# Altium schematic sheet Raijin_Motors.SchDoc
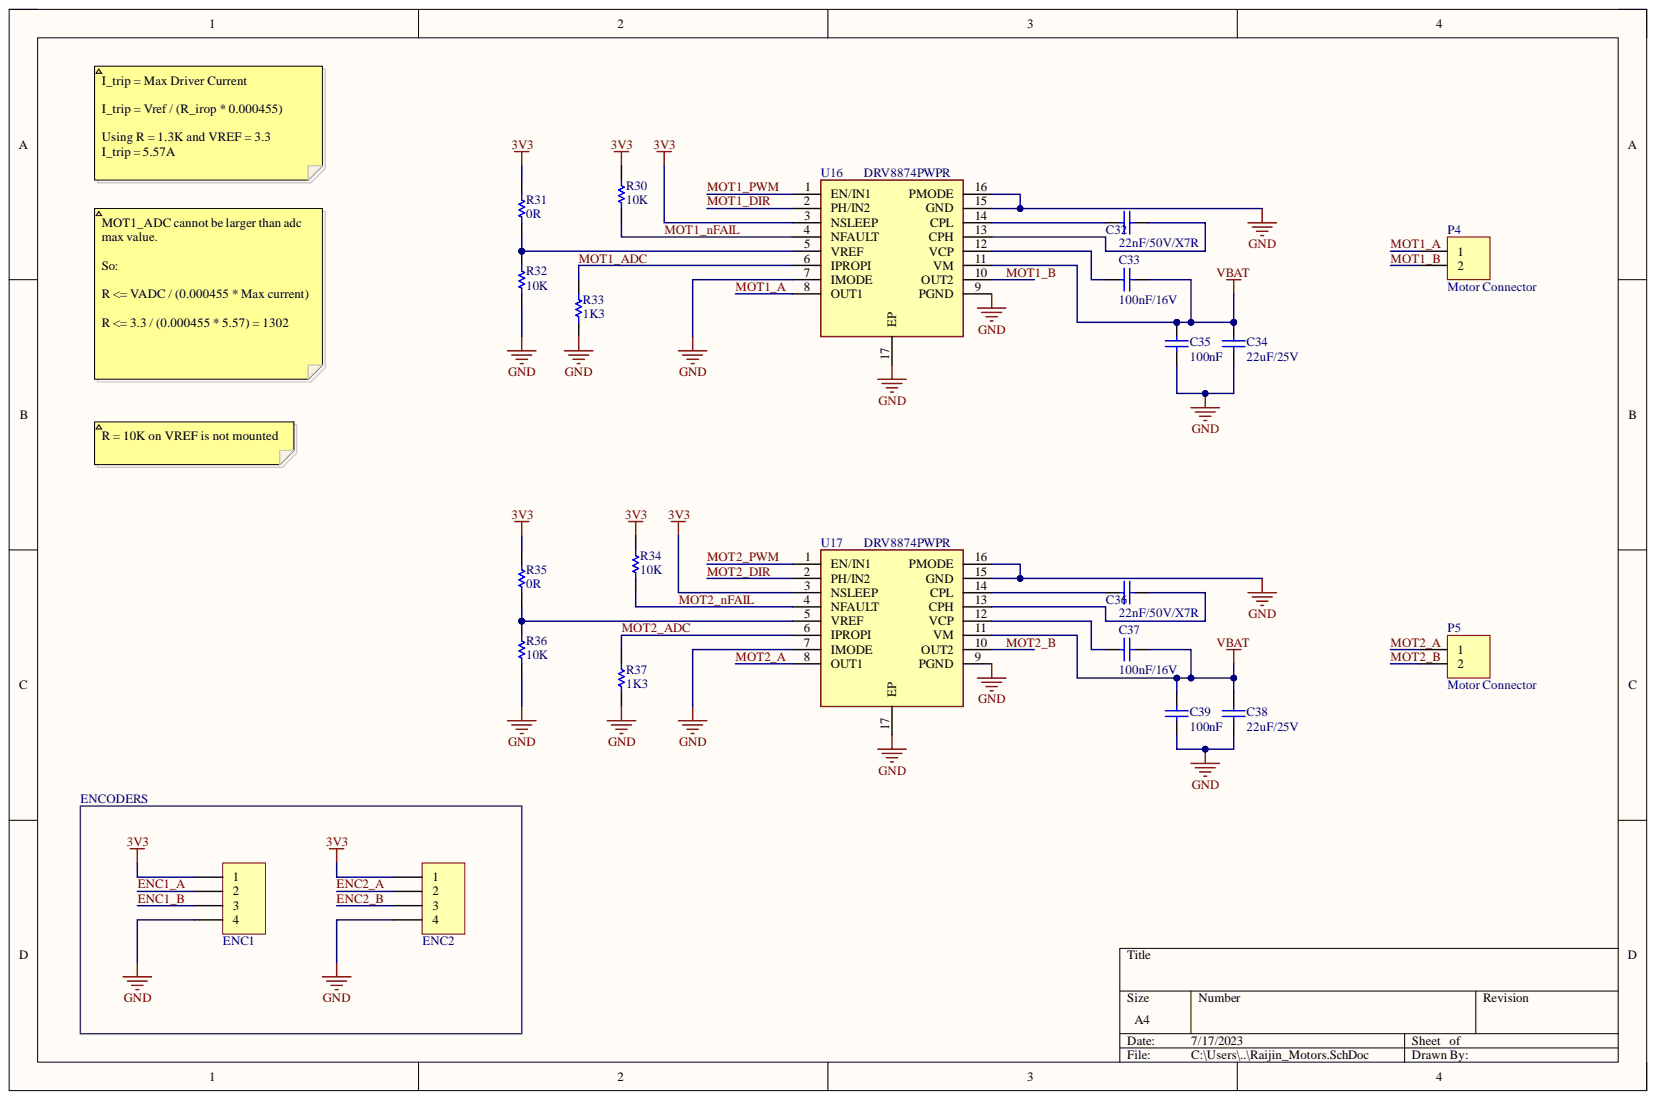
[diagram p0: Raijin_Motors.SchDoc]

## Parts
C32  22nF/50V/X7R  [Capacitor-0603]
C33  100nF/16V  [Capacitor-0603]
C34  22uF/25V  [CAPACITOR-0805]
C35  100nF  [Capacitor-0603]
C36  22nF/50V/X7R  [Capacitor-0603]
C37  100nF/16V  [Capacitor-0603]
C38  22uF/25V  [CAPACITOR-0805]
C39  100nF  [Capacitor-0603]
ENC1  Header 1x4  [HDR1X4]  (value not drawn)
ENC2  Header 1x4  [HDR1X4]  (value not drawn)
P4  Motor Connector  [MOTOR_CONNECTOR]
P5  Motor Connector  [MOTOR_CONNECTOR]
R30  10K  [RESISTOR 0603]
R31  0R  [RESISTOR 0603]
R32  10K  [RESISTOR 0603]
R33  1K3  [RESISTOR 0603]
R34  10K  [RESISTOR 0603]
R35  0R  [RESISTOR 0603]
R36  10K  [RESISTOR 0603]
R37  1K3  [RESISTOR 0603]
U16  DRV8874PWPR  [SOP65P640X120-17N]
U17  DRV8874PWPR  [SOP65P640X120-17N]

## Nets
3V3: ENC1.1 ENC2.1 R30.2 R31.2 R34.2 R35.2 U16.3(NSLEEP) U17.3(NSLEEP)
GND: C34.1 C35.1 C38.1 C39.1 ENC1.4 ENC2.4 R32.1 R33.1 R36.1 R37.1 U16.7(IMODE) U16.9(PGND) U16.15(GND) U16.16(PMODE) U16.17(EP) U17.7(IMODE) U17.9(PGND) U17.15(GND) U17.16(PMODE) U17.17(EP)
VBAT: C33.1 C34.2 C35.2 C37.1 C38.2 C39.2 U16.11(VM) U17.11(VM)
ENC1_A: ENC1.2
ENC1_B: ENC1.3
ENC2_A: ENC2.2
ENC2_B: ENC2.3
MOT1_A: P4.1 U16.8(OUT1)
MOT1_ADC: R33.2 U16.6(IPROPI)
MOT1_B: P4.2 U16.10(OUT2)
MOT1_DIR: U16.2(PH/IN2)
MOT1_nFAIL: R30.1 U16.4(NFAULT)
MOT1_PWM: U16.1(EN/IN1)
MOT2_A: P5.1 U17.8(OUT1)
MOT2_ADC: R37.2 U17.6(IPROPI)
MOT2_B: P5.2 U17.10(OUT2)
MOT2_DIR: U17.2(PH/IN2)
MOT2_nFAIL: R34.1 U17.4(NFAULT)
MOT2_PWM: U17.1(EN/IN1)
NetC32_1: C32.1 U16.14(CPL)
NetC32_2: C32.2 U16.13(CPH)
NetC33_2: C33.2 U16.12(VCP)
NetC36_1: C36.1 U17.14(CPL)
NetC36_2: C36.2 U17.13(CPH)
NetC37_2: C37.2 U17.12(VCP)
NetR31_1: R31.1 R32.2 U16.5(VREF)
NetR35_1: R35.1 R36.2 U17.5(VREF)

## Library data of the parts
C32 C36 = CAP 22nF: Capacitor Cer 22nF 50V; Manufacturer Part Number: IMP14925; Capacitance Tolerance: +80%, -20%; Capacitor Terminals: Radial Leaded; Dielectric Characteristic: Y5; Product Range: MCFY Series; SVHC: No SVHC (15-Jun-2015); Voltage Rating: 50; Capacitance Tolerance ±: +80%, -20%; Component Kind: Standard; ComponentLink1Description: http://loja.multcomercial.com.br/capacitor-ceramico-disco-100kpf-50v-104-100k-100nf-0-1uf-cod-1351.html; ComponentLink1URL: http://loja.multcomercial.com.br/capacitor-ceramico-disco-100kpf-50v-104-100k-100nf-0-1uf-cod-1351.html; Lead Spacing: 5; Manufacturer: -; Operating Temperature Max: 85; Operating Temperature Min: -25; Operating Temperature Range: -25°C to +85°C<!--FANDB--><div class="features-and-benefits-container"><div class="features-and-benefits-description"><span class="features-benefits-value">The MCFY series from Multicomp are radial leaded ceramic disc capacitors. The capacitance values ranges from 6800pF to 0.22?F.</span></div><div class="features-and-benefits-list"><ul class="features-and-benefits-list-list"><li class="features-and-benefits-list-item">Large capacitance in small size</li><li class="features-and-benefits-list-item">Rated voltage of 50V</li><li class="features-and-benefits-list-item">80%/-20% tolerance</li><li class="features-and-benefits-list-item">Operating temperature range -25°C to +85; Packaging: Each; Pricing 1: 1=0.10 (BRL); RoHS: YES; Stock 1: 115809; Supplier 1: Mult Comercial; Supplier Part Number 1: Capacitor Cerâmico Disco 100Kpf/50V (104/100K/100nF/0.1uF) cód. 1351; Library Name: RaijinLib.SchLib
C33 C37 = CAP 100NF 16V: Capacitor Cer 100nF 16VX7R; Manufacturer Part Number: IMP14925; Capacitance: 0.1uF; Capacitance Tolerance: +80%, -20%; Capacitor Terminals: Radial Leaded; Dielectric Characteristic: Y5; Product Range: MCFY Series; SVHC: No SVHC (15-Jun-2015); Voltage Rating: 50; Capacitance Tolerance ±: +80%, -20%; Component Kind: Standard; ComponentLink1Description: http://loja.multcomercial.com.br/capacitor-ceramico-disco-100kpf-50v-104-100k-100nf-0-1uf-cod-1351.html; ComponentLink1URL: http://loja.multcomercial.com.br/capacitor-ceramico-disco-100kpf-50v-104-100k-100nf-0-1uf-cod-1351.html; Lead Spacing: 5; Manufacturer: -; Operating Temperature Max: 85; Operating Temperature Min: -25; Operating Temperature Range: -25°C to +85°C<!--FANDB--><div class="features-and-benefits-container"><div class="features-and-benefits-description"><span class="features-benefits-value">The MCFY series from Multicomp are radial leaded ceramic disc capacitors. The capacitance values ranges from 6800pF to 0.22?F.</span></div><div class="features-and-benefits-list"><ul class="features-and-benefits-list-list"><li class="features-and-benefits-list-item">Large capacitance in small size</li><li class="features-and-benefits-list-item">Rated voltage of 50V</li><li class="features-and-benefits-list-item">80%/-20% tolerance</li><li class="features-and-benefits-list-item">Operating temperature range -25°C to +85; Packaging: Each; Pricing 1: 1=0.10 (BRL); RoHS: YES; Stock 1: 115809; Supplier 1: Mult Comercial; Supplier Part Number 1: Capacitor Cerâmico Disco 100Kpf/50V (104/100K/100nF/0.1uF) cód. 1351; Library Name: RaijinLib.SchLib
C34 C38 = CAP CER 22UF 25V X5R 0805: Manufacturer: AVX Corporation; Manufacturer Part Number: 0805YD106KAT2A; Capacitance: 10µF; Tolerance: ±10%; Voltage - Rated: 16V; Applications: General Purpose; Category: Capacitors; Component Kind: Standard; ComponentLink1Description: http://datasheets.avx.com/cx5r.pdf; ComponentLink1URL: https://www.lcsc.com/product-detail/Multilayer-Ceramic-Capacitors-MLCC-SMD-SMT_Murata-Electronics-GRM21BR61E226ME44L_C86816.html; Description: CAP CER 22UF 25V X5R 0805; Features: -; Height - Seated (Max): -; Lead Spacing: -; Lead Style: -; Mounting Type: Surface Mount, MLCC; Operating Temperature: -55°C ~ 85°C; Package / Case: 0805 (2012 Metric); Packaging: Cut Tape (CT); Part Status: Active; Pricing 1: 1=0.18, 10=0.124, 25=0.0908, 50=0.0742, 100=0.0627, 250=0.05116, 500=0.0429, 1000=0.03795 (USD); Ratings: -; RoHS: RoHS Compliant; Size / Dimension: 0.079" L x 0.049" W (2.01mm x 1.25mm); Stock 1: 198871; Supplier 1: Digi-Key; Supplier Part Number 1: 478-5165-1-ND; Temperature Coefficient: X5R; Thickness (Max): 0.055" (1.40mm); Library Name: RaijinLib.SchLib
C35 C39 = CAP 100NF 50V: Capacitor Cer 100nF 50V; Manufacturer Part Number: IMP14925; Capacitance: 0.1uF; Capacitance Tolerance: +80%, -20%; Capacitor Terminals: Radial Leaded; Dielectric Characteristic: Y5; Product Range: MCFY Series; SVHC: No SVHC (15-Jun-2015); Voltage Rating: 50; Capacitance Tolerance ±: +80%, -20%; Component Kind: Standard; ComponentLink1Description: http://loja.multcomercial.com.br/capacitor-ceramico-disco-100kpf-50v-104-100k-100nf-0-1uf-cod-1351.html; ComponentLink1URL: http://loja.multcomercial.com.br/capacitor-ceramico-disco-100kpf-50v-104-100k-100nf-0-1uf-cod-1351.html; Lead Spacing: 5; Manufacturer: -; Operating Temperature Max: 85; Operating Temperature Min: -25; Operating Temperature Range: -25°C to +85°C<!--FANDB--><div class="features-and-benefits-container"><div class="features-and-benefits-description"><span class="features-benefits-value">The MCFY series from Multicomp are radial leaded ceramic disc capacitors. The capacitance values ranges from 6800pF to 0.22?F.</span></div><div class="features-and-benefits-list"><ul class="features-and-benefits-list-list"><li class="features-and-benefits-list-item">Large capacitance in small size</li><li class="features-and-benefits-list-item">Rated voltage of 50V</li><li class="features-and-benefits-list-item">80%/-20% tolerance</li><li class="features-and-benefits-list-item">Operating temperature range -25°C to +85; Packaging: Each; Pricing 1: 1=0.10 (BRL); RoHS: YES; Stock 1: 115809; Supplier 1: Mult Comercial; Supplier Part Number 1: Capacitor Cerâmico Disco 100Kpf/50V (104/100K/100nF/0.1uF) cód. 1351; Library Name: RaijinLib.SchLib
ENC1 ENC2 = Header 1x4: Headers & Wire Housings 40Pin 2mm Spacing Single Row Hdrs, 5pc; Manufacturer Part Number: IMP10327; Brand: Cromax; Mounting Angle: Vertical; Number of Positions: 40 Position; Accessory Type: -; Category: Headers & Wire Housings; Component Kind: Standard; ComponentLink1Description: http://loja.multcomercial.com.br/barra-de-pinos-simples-1x40-macho-bmo40-1e.html; ComponentLink1URL: http://loja.multcomercial.com.br/barra-de-pinos-simples-1x40-macho-bmo40-1e.html; Contact Gender: Pin (Male); Contact Plating: Gold; Contact Type: -; Manufacturer: Cromax; Minimum Order Quantity: 1; Mounting Style: Straight Pin; Number of Rows: 1 Row; Pitch: 2 mm; Pricing 1: 1=1.00, 5=0.20 (BRL); Product: Headers; Product Category: Accessories; Reeling: False; RoHS: RoHS Compliant; RoHS - Mouser: Y; Row Spacing: -; Stock 1: 14; Supplier 1: Mult Comercial; Supplier Part Number 1: Barra de Pinos Simples 1x40 Macho BMO40-1E; Termination Style: Through Hole; Type: Breakaway; Library Name: RaijinLib.SchLib
R30 R32 R34 R36 = RES 10K 5%: Manufacturer: PANASONIC ELECTRONIC COMPONENTS; Manufacturer Part Number: ERJ-3GEYJ103V; Power (Watts): 0.1W, 1/10W; Temperature Coefficient: ±200ppm/°C; Tolerance: ±5%; Category: Resistors; Component Kind: Standard; ComponentLink1Description: http://www.farnell.com/datasheets/1678796.pdf; ComponentLink1URL: http://www.farnell.com/datasheets/1678796.pdf; ComponentLink2Description: http://industrial.panasonic.com/www-cgi/jvcr13pz.cgi?E+PZ+3+AOA0001+ERJ3GEYJ103V+7+WW; ComponentLink2URL: http://industrial.panasonic.com/www-cgi/jvcr13pz.cgi?E+PZ+3+AOA0001+ERJ3GEYJ103V+7+WW; Composition: Thick Film; Features: Automotive AEC-Q200; Height: 0.022" (0.55mm); Number of Terminations: 2; Operating Temperature: -55°C ~ 155°C; Package / Case: 0603 (1608 Metric); Packaging: Tape & Reel (TR); Resistance (Ohms): 10k; RoHS: YES; Size / Dimension: 0.063" L x 0.031" W (1.60mm x 0.80mm); Supplier Device Package: 0603; Library Name: RaijinLib.SchLib
R31 R35 = RES 0R: Manufacturer: Ty-Ohm; Manufacturer Part Number: CR25; Power (Watts): 0.25W, 1/4W; Temperature Coefficient: 0/ -500ppm/°C; Tolerance: ±5%; Category: Resistors; Component Kind: Standard; ComponentLink1Description: http://loja.multcomercial.com.br/resistor-de-1-4-de-watt-cr25-5-de-tolerancia.html; ComponentLink1URL: http://loja.multcomercial.com.br/resistor-de-1-4-de-watt-cr25-5-de-tolerancia.html; Composition: Carbon Film; Features: Flame Retardant Coating, Safety; Height: -; Number of Terminations: 2; Operating Temperature: -55°C ~ 155°C; Package / Case: Axial; Packaging: Cut Tape (CT); Pricing 1: 1=0.20 (BRL); Resistance (Ohms): ?; RoHS: RoHS Compliant; Size / Dimension: 0.091" Dia x 0.256" L (2.30mm x 6.50mm); Stock 1: 617543; Supplier 1: Mult Comercial; Supplier Device Package: Axial; Supplier Part Number 1: Resistor de Filme de Carbono 1/4 de Watt CR25 5% de tolerância - 0.22R Ω à 10M Ω; Library Name: RaijinLib.SchLib
R33 R37 = RES 1K3 1%: Resistor 1K3 1% tolerance; Manufacturer: Ty-Ohm; Manufacturer Part Number: CR25; Power (Watts): 0.25W, 1/4W; Temperature Coefficient: 0/ -500ppm/°C; Tolerance: ±5%; Category: Resistors; Component Kind: Standard; ComponentLink1Description: http://loja.multcomercial.com.br/resistor-de-1-4-de-watt-cr25-5-de-tolerancia.html; ComponentLink1URL: http://loja.multcomercial.com.br/resistor-de-1-4-de-watt-cr25-5-de-tolerancia.html; Composition: Carbon Film; Features: Flame Retardant Coating, Safety; Height: -; Number of Terminations: 2; Operating Temperature: -55°C ~ 155°C; Package / Case: Axial; Packaging: Cut Tape (CT); Pricing 1: 1=0.20 (BRL); Resistance (Ohms): ?; RoHS: RoHS Compliant; Size / Dimension: 0.091" Dia x 0.256" L (2.30mm x 6.50mm); Stock 1: 617543; Supplier 1: Mult Comercial; Supplier Device Package: Axial; Supplier Part Number 1: Resistor de Filme de Carbono 1/4 de Watt CR25 5% de tolerância - 0.22R Ω à 10M Ω; Library Name: RaijinLib.SchLib
U16 U17 = DRV8874PWPR: Integrated Circuit; Manufacturer_Name: Texas Instruments; Arrow Part Number: DRV8874PWPR; Arrow Price/Stock: https://www.arrow.com/en/products/drv8874pwpr/texas-instruments?region=nac; Component Kind: Standard; Datasheet Link: https://www.ti.com/lit/gpn/DRV8874; Height: 1.2mm; Manufacturer_Part_Number: DRV8874PWPR; Mouser Part Number: 595-DRV8874PWPR; Mouser Price/Stock: https://www.mouser.co.uk/ProductDetail/Texas-Instruments/DRV8874PWPR?qs=mAH9sUMRCtvh%2FSU15ywmDA%3D%3D; Library Name: RaijinLib.SchLib
P4 P5 = Motor Connector: Component Kind: Standard; Library Name: RaijinLib.SchLib
